# Altium schematic sheet ESP32 Dongle.SchDoc("ESP32 Dongle")
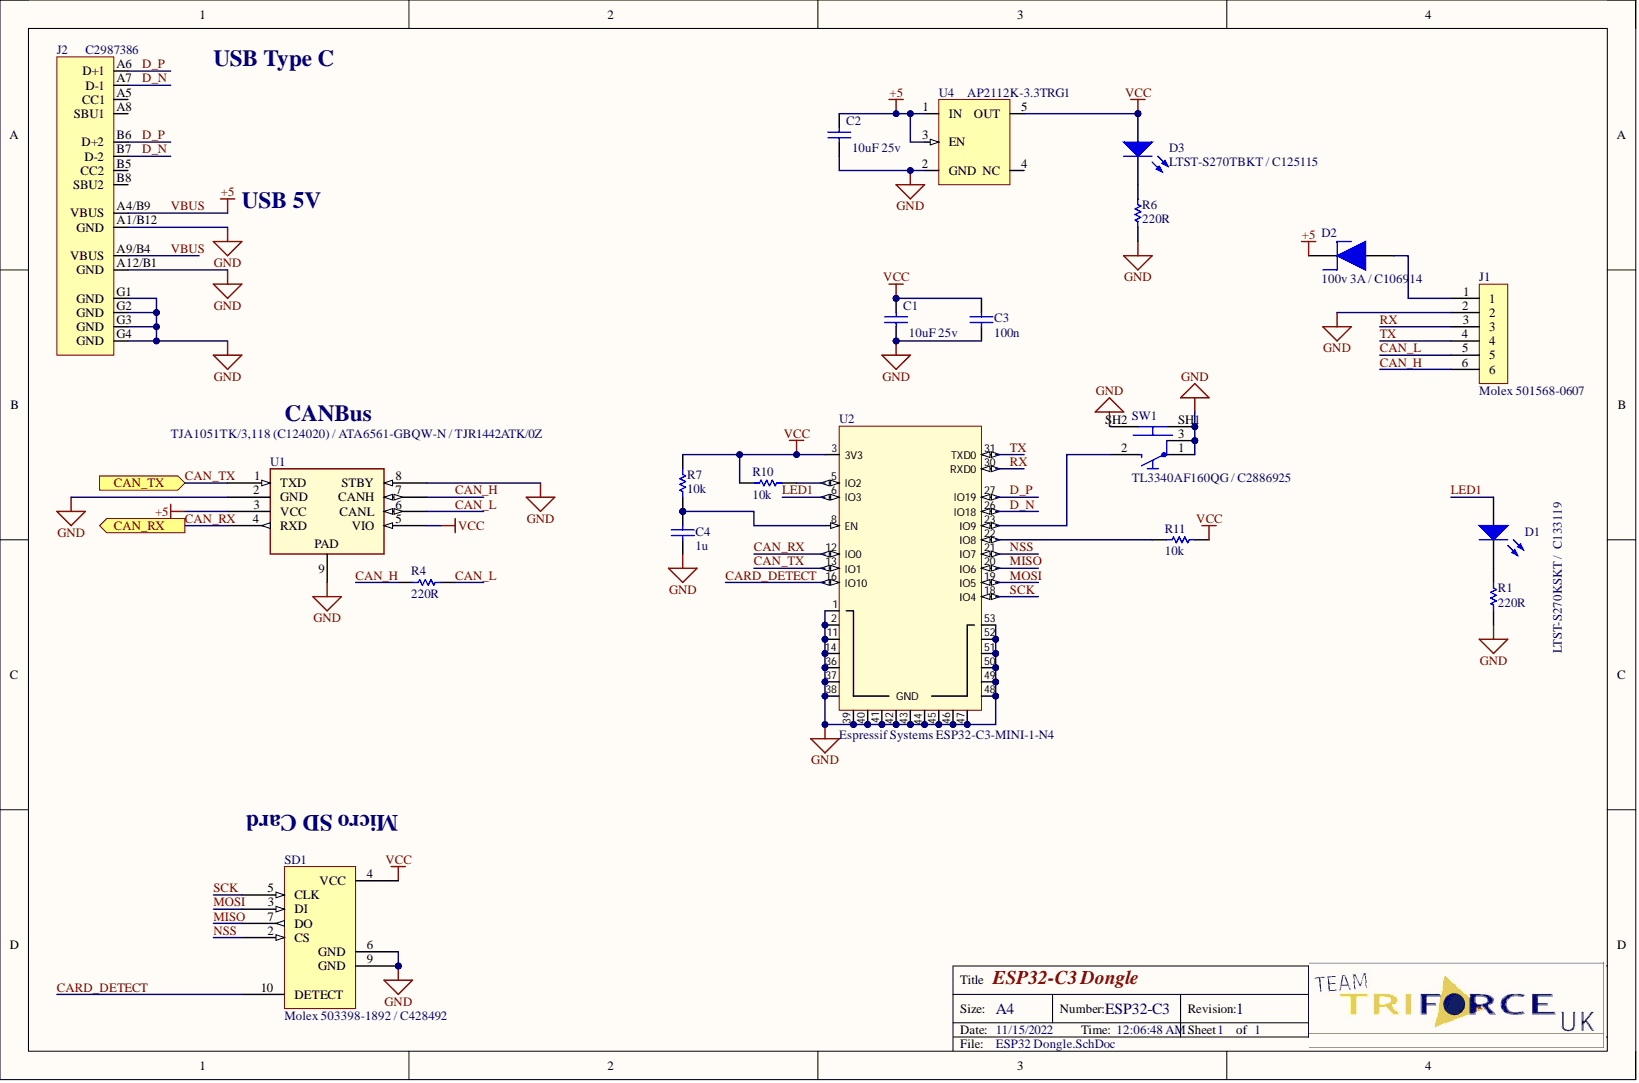
[diagram p0: ESP32 Dongle.SchDoc]

## Parts
C1  10uF 25v
C2  10uF 25v
C3  100n
C4  1u
D1  LTST-S270KSKT /  C133119
D2  100v 3A / C106914
D3  LTST-S270TBKT / C125115
J1  Molex 501568-0607  Manufacturer Part Number: 501568-0607  [PCB-uijb912ttj3luwhgcqno-7]
J2  C2987386
R1  220R
R4  220R
R6  220R
R7  10k
R10  10k
R11  10k
SD1  Molex 503398-1892 / C428492  [PCB-12527065-1]
SW1  TL3340AF160QG / C2886925  Manufacturer Part No.: TL3340AF160QG  [PCB-ra6c6pi8r10ckzxg4s2r-1]
U1  TJA1051TK/3,118 (C124020) / ATA6561-GBQW-N / TJR1442ATK/0Z
U2  Espressif Systems ESP32-C3-MINI-1-N4  Manufacturer: Espressif  Manufacturer Part Number: ESP32-C3-MINI-1-N4  [PCB-k4zv3h5xtuqaodquxavs-1]
U4  AP2112K-3.3TRG1

## Ports
CAN_RX, CAN_TX

## Nets
GND: C1.1 C2.1 C3.1 C4.1 J1.2 J2.A1/B12(GND) J2.A12/B1(GND) J2.G1(GND) J2.G2(GND) J2.G3(GND) J2.G4(GND) R1.2 R6.2 SD1.6(GND) SD1.9(GND) SW1.1 SW1.3 SW1.SH1 SW1.SH2 U1.2(GND) U1.8(STBY) U1.9 U2.1 U2.2 U2.11 U2.14 U2.36 U2.37 U2.38 U2.39 U2.40 U2.41 U2.42 U2.43 U2.44 U2.45 U2.46 U2.47 U2.48 U2.49 U2.50 U2.51 U2.52 U2.53 U4.2(GND)
VCC: C1.2 C3.2 D3.1 R7.1 R10.1 R11.1 SD1.4(VCC) U1.5(VIO) U2.3(3V3) U4.5(OUT)
CAN_H: J1.6 R4.2 U1.7(CANH)
CAN_L: J1.5 R4.1 U1.6(CANL)
CAN_RX: U1.4(RXD) U2.12(IO0) ; port CAN_RX
CAN_TX: U1.1(TXD) U2.13(IO1) ; port CAN_TX
CARD_DETECT: SD1.10(DETECT) U2.16(IO10)
D_N: J2.A7(D-1) J2.B7(D-2) U2.26(IO18)
D_P: J2.A6(D+1) J2.B6(D+2) U2.27(IO19)
LED1: D1.1 U2.6(IO3)
MISO: SD1.7(DO) U2.20(IO6)
MOSI: SD1.3(DI) U2.19(IO5)
NSS: SD1.2(CS) U2.21(IO7)
RX: J1.3 U2.30(RXD0)
SCK: SD1.5(CLK) U2.18(IO4)
TX: J1.4 U2.31(TXD0)
VBUS: C2.2 D2.2 J2.A4/B9(VBUS) J2.A9/B4(VBUS) U1.3(VCC) U4.1(IN) U4.3(EN)
NetC4_2: C4.2 R7.2 U2.8(EN)
NetD1_2: D1.2 R1.1
NetD2_1: D2.1 J1.1
NetD3_2: D3.2 R6.1
NetR10_2: R10.2 U2.5(IO2)
NetR11_2: R11.2 U2.22(IO8)
NetSW1_2: SW1.2 U2.23(IO9)

Not connected: J2 A5(CC1) A8(SBU1) B5(CC2) B8(SBU2); U4 4(NC)

## Library data of the parts
C1 C2 = CMP-127211-2: CAP CER 2.2UF 16V 10% X5R 0603; Manufacturer Part Number: 0603YD225KAT2A; Capacitance: 2.2e-06 F; Dielectric Material: Ceramic Multilayer; Lead-Free Status: Lead Free; Voltage Rating (DC): 16.0 V; Capacitance Tolerance: ±10%; Case/Package: 0603; Component Kind: Standard; Dielectric Characteristic: X5R; Library Name: My Personal Space; Mounting Style: Surface Mount; Number of Pins: 2; Octopart Url: https://octopart.com/0603yd225kat2a-avx+interconnect+%2F+elco-7145579; OctopartID: 5fad96ca72a0806a; Packaging: Cut Tape (CT); REACH SVHC Compliance: No SVHC; RoHS: Compliant
C3 C4 = 04025A330JAT2A: Capacitance: 3.3e-11 F; Capacitance Tolerance: ±5%; Dielectric Characteristic: C0G/NP0; Dielectric Material: Ceramic Multilayer; Lead-Free Status: Lead Free; Temperature Coefficient: 0.0 ppm/°C; Voltage Rating (DC): 50.0 V; Case/Package: 0402; Component Kind: Standard; Library Name: Octopart library; Manufacturer Part Number: 04025A330JAT2A; Mounting Style: Surface Mount; Number of Pins: 2; Octopart Url: https://octopart.com/04025a330jat2a-avx+interconnect+%2F+elco-46507913; OctopartID: 2827e3e8aac8f7e0; REACH SVHC Compliance: No SVHC; RoHS: Compliant; Size-Height: 0.00056 m; Size-Length: 0.001 m; Size-Width: 0.0005 m
D1 D3 = CMP-1417dd155542cbd0-7: LED; SMD; 0604; red; 18-54mcd; 1.6x1.15x0.6mm; 130°; 2÷2.4V; 20mA; Manufacturer: Lite-On; Manufacturer Part Number: LTST-S270KRKT; Color: Red; Component Kind: Standard; Library Name: My Personal Space
D2 = MBR2H200SFT1G: REC SOD123 2A 200V; Lead-Free Status: Lead Free; Component Kind: Standard; Library Name: Octopart library; Lifecycle Status: Active; Manufacturer Part Number: MBR2H200SFT1G; Number of Pins: 2; Octopart Url: https://octopart.com/mbr2h200sft1g-on+semiconductor-51167333; OctopartID: 23fee9f8c6fdfc39; REACH SVHC Compliance: No SVHC; RoHS: Compliant
J1 = CMP-56be9fa140978979-4: 1.00mm Pitch Pico-Clasp™ Wire-to-Board Header, Surface Mount, Single Row, Right Angle, with Positive Lock, 6 Circuits...; Current Rating: 1.00 A; Gender: Male; Glow Wire Compliant: Non-Compliant; Lead-Free Status: Lead Free; Number of Circuits: 6; Voltage Rating (DC): 50.0 V; Color: Natural; Component Kind: Standard; Contact Material: Brass Alloy; Contact Plating: Tin; Halogen Free Status: Not Low Halogen; Housing Color: Natural; Housing Material: Thermoplastic; Library Name: My Personal Space; Lifecycle Status: Active; Mounting Style: Surface Mount; Number of Contacts: 6; Number of Positions: 6; Number of Rows: 1; Octopart URL: http://octopart.com/501568-0607-molex-1389391; OctopartID: 56be9fa140978979; Orientation: Right Angle; Packaging: Cut Tape (CT); Pin Pitch: 1.00 mm; REACH SVHC Compliance: No SVHC; RoHS: Compliant
J2 = CMP-02a6cdcbf5c5dd61-8: Conn USB 2.0 Type C RCP 16 POS 0.3mm/0.5mm Solder RA SMD 16 Terminal 1 Port T/R; Manufacturer: GTC; Manufacturer Part Number: USB4105-GF-A; Contacts: 16; USB: USB 2.0; USB Type: Type C; Component Kind: Standard; Library Name: My Personal Space; Octopart Url: https://octopart.com/usb4105-gf-a-global+connector+technology-107467269; OctopartID: 02a6cdcbf5c5dd61
R1 R4 R6 R7 R10 R11 = ERJ-2GEJ201X: Thick Film Resistors - SMD 0402 200ohms 5% Tol; Lead-Free Status: Lead Free; Power Rating: 0.1 W; Resistance: 200.0 Ω; Voltage Rating (DC): 50.0 V; Case/Package: 0402; Component Kind: Standard; Library Name: Octopart library; Lifecycle Status: Not Listed by Manufacturer; Manufacturer Part Number: ERJ-2GEJ201X; Mounting Style: Surface Mount; Octopart Url: https://octopart.com/erj-2gej201x-panasonic-55529170; OctopartID: c9b003f5a2041677; Packaging: Cut Tape (CT); REACH SVHC Compliance: No SVHC; Resistance Tolerance: ±5%; RoHS: Compliant; Size-Height: 0.00035 m; Size-Length: 0.001 m; Size-Width: 0.0005 m
SD1 = CMP-12527065-13: Conn Micro SD Card SKT 8 POS 1.1mm Solder RA SMD 0.5A/Contact Embossed T/R; Component Kind: Standard; Library Name: My Personal Space
SW1 = CMP-1ec0d7a19391c107-3: TL3340 Series SPST 2.6 N Round Button Gull Wing SMT Sub-Miniature Tactile Switch; Manufacturer Name: E-Switch; Lead-Free Status: Lead Free; Component Kind: Standard; Contacts Type: SPST; Library Name: My Personal Space; Manufacturer Part Number: TL3340AF160QG; Mounting Style: PCB; Octopart URL: http://octopart.com/tl3340af160qg-e-switch-7055415; OctopartID: 1ec0d7a19391c107; RoHS: Compliant
U1 = ATA6561-GBQW: CAN 5Mbps Normal/Silent/Standby 5V Automotive 8-Pin VDFN EP T/R; Component Kind: Standard; Library Name: Octopart library; Manufacturer Part Number: ATA6561-GBQW; Octopart URL: http://octopart.com/ata6561-gbqw-atmel-50991840; OctopartID: 9a565056add04591; RoHS: Compliant
U2 = CMP-8ad14528aa205516-7: SMD Module, ESP32-C3FN4, Pcb Ant; Component Kind: Standard; Library Name: My Personal Space; Octopart URL: https://octopart.com/esp32-c3-mini-1-n4-espressif+systems-116589975; OctopartID: 8ad14528aa205516
U4 = AP2112K-3.3TRG1: AP2112 Series 0.6 A 3.3 V Fixed Output SMT LDO Linear Regulator - SOT23-5; Lead-Free Status: Lead Free; Component Kind: Standard; Library Name: Octopart library; Lifecycle Status: Not Listed by Manufacturer; Manufacturer Part Number: AP2112K-3.3TRG1; Octopart Url: http://octopart.com/ap2112k-3.3trg1-diodes+inc.-30954557; OctopartID: 0ec2c6107f99d105; RoHS: Compliant; Seller Landing  Url: https://octopart-clicks.com/click/track?country=US&ak=8157f166&sig=01867c6&sid=459&ppid=30954557&vpid=95776131&ct=offers
